# Altium schematic sheet Sheet1.SchDoc(Sheet1)
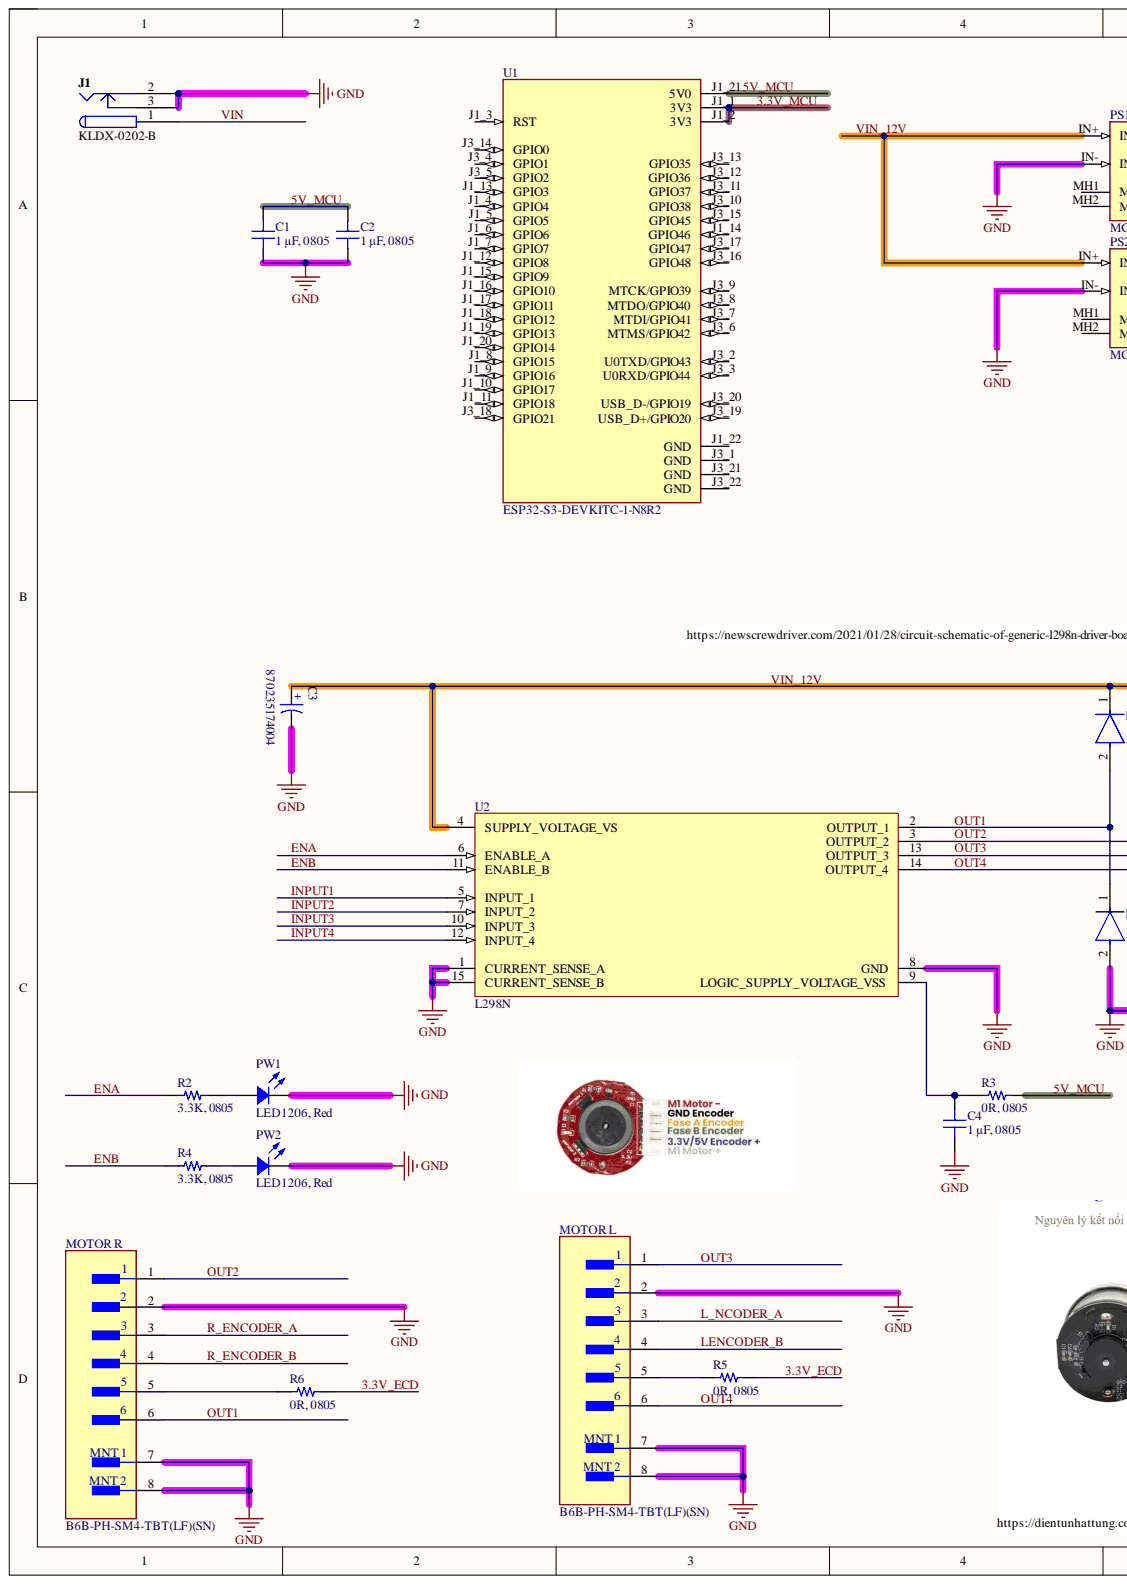
[diagram p0: Sheet1.SchDoc, left]
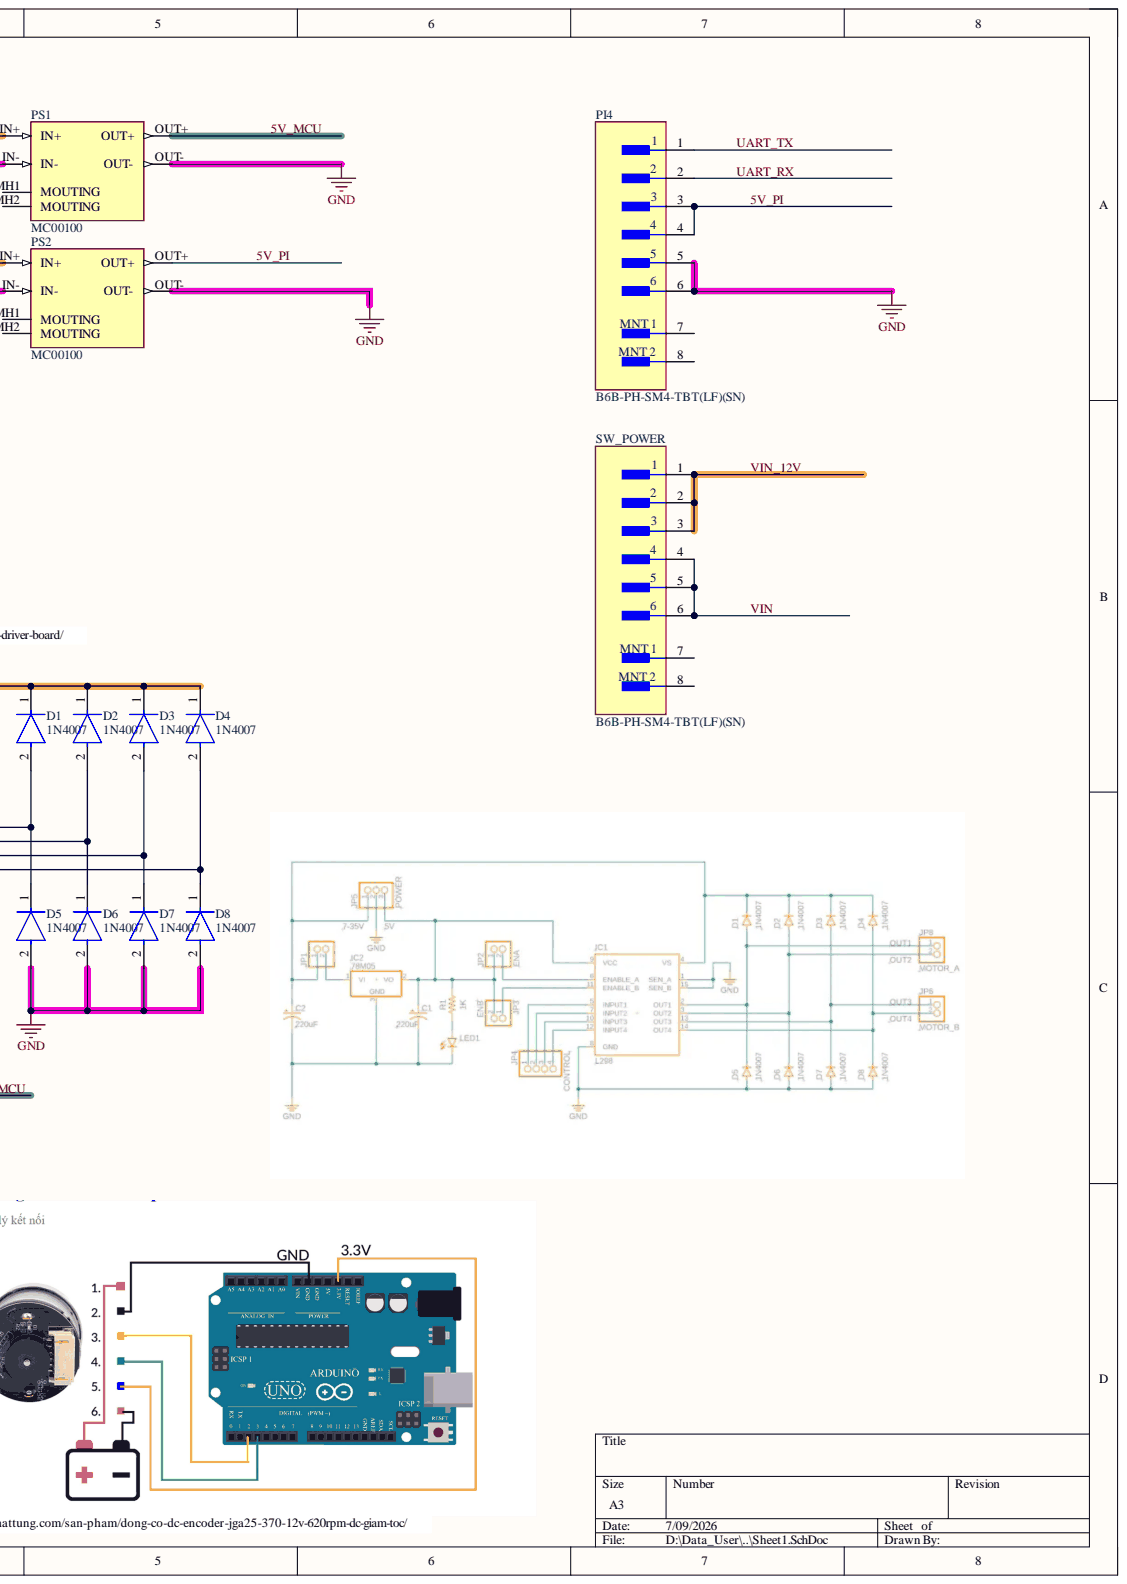
[diagram p1: Sheet1.SchDoc, right]

## Parts
C1  1 µF  [CAP 0805_2012]  (value not drawn)
C2  Capacitance: 1 µF, 0805  [CAP 0805_2012]  (hidden comment: 1 µF)
C3  870235174004  [WCAP-PT5H_D8H11.5]
C4  Capacitance: 1 µF, 0805  [CAP 0805_2012]  (hidden comment: 1 µF)
D1  1N4007  [FP-1N4007-MFG]
D2  1N4007  [FP-1N4007-MFG]
D3  1N4007  [FP-1N4007-MFG]
D4  1N4007  [FP-1N4007-MFG]
D5  1N4007  [FP-1N4007-MFG]
D6  1N4007  [FP-1N4007-MFG]
D7  1N4007  [FP-1N4007-MFG]
D8  1N4007  [FP-1N4007-MFG]
J1  KLDX-0202-B  [KYCN-KLDX-0202-B_V]
MOTOR_L  B6B-PH-SM4-TBT(LF)(SN)  [FP-B6B-PH-SM4-TBT_LF_SN-MFG]
MOTOR_R  B6B-PH-SM4-TBT(LF)(SN)  [FP-B6B-PH-SM4-TBT_LF_SN-MFG]
PI4  B6B-PH-SM4-TBT(LF)(SN)  [FP-B6B-PH-SM4-TBT_LF_SN-MFG]
PS1  MC00100  [CONV_MC00100]
PS2  MC00100  [CONV_MC00100]
PW1  LED1206, Red  value Red  Color: Red  [LED 1206_3216 RED]
PW2  LED1206, Red  value Red  Color: Red  [LED 1206_3216 RED]
R2  3.3K, 0805  Supplier Device Package: 0805  [YAGEO RES 0805_2012]
R3  0R, 0805  Supplier Device Package: 0805  [YAGEO RES 0805_2012]
R4  3.3K, 0805  Supplier Device Package: 0805  [YAGEO RES 0805_2012]
R5  0R, 0805  Supplier Device Package: 0805  [YAGEO RES 0805_2012]
R6  0R, 0805  Supplier Device Package: 0805  [YAGEO RES 0805_2012]
SW_POWER  B6B-PH-SM4-TBT(LF)(SN)  [FP-B6B-PH-SM4-TBT_LF_SN-MFG]
U1  ESP32-S3-DEVKITC-1-N8R2  [XCVR_ESP32-S3-DEVKITC-1-N8R2]
U2  L298N  [L298N_MFG]

## Nets
GND: C1.1 C2.1 C3.2 C4.1 D5.2 D6.2 D7.2 D8.2 J1.2 J1.3 MOTOR_L.2 MOTOR_L.7 MOTOR_L.8 MOTOR_R.2 MOTOR_R.7 MOTOR_R.8 PI4.5 PI4.6 PS1.IN- PS1.OUT- PS2.IN- PS2.OUT- PW1.2 PW2.2 U2.1(CURRENT_SENSE_A) U2.8(GND) U2.15(CURRENT_SENSE_B)
3.3V_ECD: R5.2 R6.2
3.3V_MCU: U1.J1_1(3V3) U1.J1_2(3V3)
5V_MCU: C1.2 C2.2 PS1.OUT+ R3.2 U1.J1_21(5V0)
5V_PI: PI4.3 PI4.4 PS2.OUT+
ENA: R2.1 U2.6(ENABLE_A)
ENB: R4.1 U2.11(ENABLE_B)
INPUT1: U2.5(INPUT_1)
INPUT2: U2.7(INPUT_2)
INPUT3: U2.10(INPUT_3)
INPUT4: U2.12(INPUT_4)
L_NCODER_A: MOTOR_L.3
LENCODER_B: MOTOR_L.4
OUT1: D1.2 D5.1 MOTOR_R.6 U2.2(OUTPUT_1)
OUT2: D2.2 D6.1 MOTOR_R.1 U2.3(OUTPUT_2)
OUT3: D3.2 D7.1 MOTOR_L.1 U2.13(OUTPUT_3)
OUT4: D4.2 D8.1 MOTOR_L.6 U2.14(OUTPUT_4)
R_ENCODER_A: MOTOR_R.3
R_ENCODER_B: MOTOR_R.4
UART_RX: PI4.2
UART_TX: PI4.1
VIN: J1.1 SW_POWER.4 SW_POWER.5 SW_POWER.6
VIN_12V: C3.1 D1.1 D2.1 D3.1 D4.1 PS1.IN+ PS2.IN+ SW_POWER.1 SW_POWER.2 SW_POWER.3 U2.4(SUPPLY_VOLTAGE_VS)
NetC4_2: C4.2 R3.1 U2.9(LOGIC_SUPPLY_VOLTAGE_VSS)
NetMOTOR L_5: MOTOR_L.5 R5.1
NetMOTOR R_5: MOTOR_R.5 R6.1
NetPW1_1: PW1.1 R2.2
NetPW2_1: PW2.1 R4.2

Not connected: PI4 7 8; PS1 MH1(MOUTING) MH2(MOUTING); PS2 MH1(MOUTING) MH2(MOUTING); SW_POWER 7 8; U1 J1_3(RST) J1_4(GPIO4) J1_5(GPIO5) J1_6(GPIO6) J1_7(GPIO7) J1_8(GPIO15) J1_9(GPIO16) J1_10(GPIO17) J1_11(GPIO18) J1_12(GPIO8) J1_13(GPIO3) J1_14(GPIO46) J1_15(GPIO9) J1_16(GPIO10) J1_17(GPIO11) J1_18(GPIO12) J1_19(GPIO13) J1_20(GPIO14) J1_22(GND) J3_1(GND) J3_2(U0TXD/GPIO43) J3_3(U0RXD/GPIO44) J3_4(GPIO1) J3_5(GPIO2) J3_6(MTMS/GPIO42) J3_7(MTDI/GPIO41) J3_8(MTDO/GPIO40) J3_9(MTCK/GPIO39) J3_10(GPIO38) J3_11(GPIO37) J3_12(GPIO36) J3_13(GPIO35) J3_14(GPIO0) J3_15(GPIO45) J3_16(GPIO48) J3_17(GPIO47) J3_18(GPIO21) J3_19(USB_D+/GPIO20) J3_20(USB_D-/GPIO19) J3_21(GND) J3_22(GND)

## Library data of the parts
C1 = CC0805KKX7R9BB105: CAP CER 1UF 50V X7R 0805; manufacturer: Yageo; Capacitance: 1 µF, 0805; Tolerance: ±10%; Applications: General Purpose; Component Kind: Standard; componentlink1description: Datasheet; componentlink1url: https://www.yageo.com/upload/media/product/productsearch/datasheet/mlcc/UPY-GPHC_X7R_6.3V-to-50V_18.pdf; componentlink2description: DigiKey Link; componentlink2url: https://www.digikey.com/en/products/detail/yageo/CC0805KKX7R9BB105/5195292; Device Package: 0805 (2012 Metric); lastupdated: 2/9/2024 8:15:50 PM; Lifecycle Status: Active; Minimum Order: 1; Mounting Type: Surface Mount, MLCC; Operating Temperature: -55°C ~ 125°C; Package / Case: 0805 (2012 Metric); packaging: TapeAndReel; Part Number: CC0805KKX7R9BB105; Part Status: Active; partid: 9887; price: 0.03; series: CC; Size / Dimension: 0.079" L x 0.049" W (2.00mm x 1.25mm); Supplier 1: DigiKey; Supplier Part Number 1: 311-1886-1-ND; Temperature Coefficient: X7R; Thickness (Max): 0.057" (1.45mm); value: 1 µF; Voltage - Rated: 50V; Library Name: TVC_Boad_IO_v1.IntLib
C2 C4 = CC0805KKX7R9BB105: CAP CER 1UF 50V X7R 0805; manufacturer: Yageo; Tolerance: ±10%; Applications: General Purpose; Component Kind: Standard; componentlink1description: Datasheet; componentlink1url: https://www.yageo.com/upload/media/product/productsearch/datasheet/mlcc/UPY-GPHC_X7R_6.3V-to-50V_18.pdf; componentlink2description: DigiKey Link; componentlink2url: https://www.digikey.com/en/products/detail/yageo/CC0805KKX7R9BB105/5195292; Device Package: 0805 (2012 Metric); lastupdated: 2/9/2024 8:15:50 PM; Lifecycle Status: Active; Minimum Order: 1; Mounting Type: Surface Mount, MLCC; Operating Temperature: -55°C ~ 125°C; Package / Case: 0805 (2012 Metric); packaging: TapeAndReel; Part Number: CC0805KKX7R9BB105; Part Status: Active; partid: 9887; price: 0.03; series: CC; Size / Dimension: 0.079" L x 0.049" W (2.00mm x 1.25mm); Supplier 1: DigiKey; Supplier Part Number 1: 311-1886-1-ND; Temperature Coefficient: X7R; Thickness (Max): 0.057" (1.45mm); value: 1 µF; Voltage - Rated: 50V; Library Name: TVC_Boad_IO_v1.IntLib
C3 = CMP-03913-54202634-2: WCAP-PT5H Aluminum Polymer Capacitors; Manufacturer Lifecycle Status: ACTIVE; Capacitance: 680µF; ESR (Equivalent Series Resistance): 7mΩ; Leakage Current: 428µA; Life (Hours): 5000hours; Pitch: 3.5mm; Polarity: Polar; Ripple Current: 6.1A; Tolerance: 20%; Voltage Rating: 6.3V; YEOL: 1 = Estimated lifetime > 10 years; Case/Package: Radial; Component Kind: Standard; Diameter: 8mm; Height - Seated (Max): 13.0048mm; Lead Pitch: 3.5052mm; Length: 11.5mm; Library Name: Altium Content Vault; Lifecycle Status: Production; Max Operating Temperature: 105°C; Min Operating Temperature: -55°C; Mount: Through Hole; Packaging: Tape & Box (TB); REACH SVHC: Unknown; RoHS: Compliant; Voltage Rating (DC): 6.3V
D1-D8 = CMP-123542-000001-1: Rectifier diode 1000 V 1 A DO-41, ,; Manufacturer: Diotec; Capacitance: 15pF; Forward Current: 1A; Max Junction Temp: +175°C; Max Repetitive Reverse Voltage: 1000V; Max Surge Forward Current: 27A; Peak Reverse Current: 5uA; Value: 15pF; Automotive: No; Category: Diode; Component Kind: Standard; ComponentLink2Description: Datasheet; ComponentLink2URL: https://datasheet.octopart.com/1N4007-Diotec-datasheet-62346875.pdf; Device Class L1: Discrete Semiconductors; Device Class L2: Diodes; Device Class L3: Rectifier Diodes; Height: 2.8mm; Ipc Land Pattern Name: DIOAD888W100L520D280; Lead Free: Yes; Library Name: Altium Content Vault; Manufacturer Part Number: 1N4007; Octopart: https://octopart.com/1n4007-diotec-4040411; Package: 1N4007; Reverse Voltage: 1000V; RoHS: TRUE; Temperature: -50°C to +175°C; Temperature Range High: +175°C; Temperature Range Low: -50°C
J1 = CMP-0256-00001-1: 3 Conductor Power Jack, 2 break 2 aux contacts; Component Kind: Standard; ComponentLink1Description: Manufacturer URL; ComponentLink1URL: http://kycon.com/; ComponentLink2Description: Datasheet; ComponentLink2URL: http://anacapa.kycon.com/Catalog_PDF/KLDX_0202.pdf; Contact Finish: Brass; Library Name: Altium Content Vault; Mounting Technology: Through Hole; PackageDescription: Jack, 9 x 14.5 mm Body, 2.5 mm Center Pin Diameter; PackageReference: KLDX-0202-B; PartNumber: KLDX-0202-B
MOTOR_L MOTOR_R PI4 SW_POWER = CMP-17439-000069-1: CONN HEADER SMD 6POS 2MM; Manufacturer: JST; Number of Positions: 6; Component Kind: Standard; Lead Free: Lead Free; Manufacturer Part Number: B6B-PH-SM4-TBT(LF)(SN); Mount: Surface Mount; Pitch: 2mm; Termination: Crimp; Library Name: TVC_Boad_IO_v1.IntLib
PS1 PS2 = MC00100: DC-DC LM2596 Step Down Adjustable Converter Power Supply Module 1.3V-35V; MF: YKS; Check_prices: https://www.snapeda.com/parts/MC00100/YKS/view-part/?ref=eda; Component Kind: Standard; Library Name: MC00100.IntLib; MP: MC00100; Package: None; SnapEDA_Link: https://www.snapeda.com/parts/MC00100/YKS/view-part/?ref=snap
PW1 PW2 = 5990210007F: LED RED 1206 SMD; Manufacturer: Dialight; Configuration: Standard; Current - Test: 20mA; Lens Color: 0.80mm; Lens Size: 2.00mm x 1.60mm; Lens Style: Rectangle with Flat Top; Millicandela Rating: 29mcd; Viewing Angle: 140°; Voltage - Forward (Vf) (Typ): 2.1V; Wavelength - Dominant: 633nm; Component Kind: Standard; ComponentLink1Description: Datasheet; ComponentLink1URL: http://www.dialightsignalsandcomponents.com/Assets/Brochures_And_Catalogs/Indication/CBI_SMD_new/Dialight_CBI_data_599-1206_Apr2018.pdf; ComponentLink2Description: DigiKey Link; ComponentLink2URL: https://www.digikey.com/en/products/detail/dialight/5990210007F/9385415; Device Package: 1206 (3216 Metric); Height (Max): 0.80mm; LastUpdated: 6/3/2024 8:00:41 PM; Lifecycle Status: Active; Minimum Order: 1; Mounting Type: Surface Mount; Package / Case: 1206 (3216 Metric); Packaging: TapeAndReel; Part Number: 5990210007F; Part Status: Active; PartId: 188; Price: 0.04; Series: 599; Size / Dimension: 3.20mm L x 1.60mm W; Supplier 1: DigiKey; Supplier Device Package: 1206; Supplier Part Number 1: 350-4360-1-ND; Library Name: TVC_Boad_IO_v1.IntLib
R2 R4 = RC0805FR-07470RL: RES 470 OHM 1% 1/8W 0805; Manufacturer: Yageo; Power (Watts): 0.125W, 1/8W; Resistance: 470 Ohms; Temperature Coefficient: ±100ppm/°C; Component Kind: Standard; ComponentLink1Description: Datasheet; ComponentLink1URL: https://www.yageo.com/upload/media/product/productsearch/datasheet/rchip/PYu-RC_Group_51_RoHS_L_10.pdf; ComponentLink2Description: DigiKey Link; ComponentLink2URL: https://www.digikey.com/en/products/detail/yageo/RC0805FR-07470RL/727976; Composition: Thick Film; Device Package: 0805 (2012 Metric); Features: Moisture Resistant; Height - Seated (Max): 0.024" (0.60mm); LastUpdated: 7/25/2024 9:20:22 AM; Lifecycle Status: Active; Minimum Order: 1; Number of Terminations: 2; Operating Temperature: -55°C ~ 155°C; Package / Case: 0805 (2012 Metric); Packaging: TapeAndReel; Part Number: RC0805FR-07470RL; Part Status: Active; PartId: 39406; Price: 0.01; Series: RC_L; Size / Dimension: 0.079" L x 0.049" W (2.00mm x 1.25mm); Supplier 1: DigiKey; Supplier Part Number 1: 311-470CRCT-ND; Tolerance: ±1%; Value: 3.3K, 0805; Library Name: TVC_Boad_IO_v1.IntLib
R3 R5 R6 = RC0805FR-07470RL_3: RES 0 OHM 1% 1/8W 0805; Manufacturer: Yageo; Power (Watts): 0.125W, 1/8W; Resistance: 470 Ohms; Temperature Coefficient: ±100ppm/°C; Component Kind: Standard; ComponentLink1Description: Datasheet; ComponentLink1URL: https://www.yageo.com/upload/media/product/productsearch/datasheet/rchip/PYu-RC_Group_51_RoHS_L_10.pdf; ComponentLink2Description: DigiKey Link; ComponentLink2URL: https://www.digikey.com/en/products/detail/yageo/RC0805FR-07470RL/727976; Composition: Thick Film; Device Package: 0805 (2012 Metric); Features: Moisture Resistant; Height - Seated (Max): 0.024" (0.60mm); LastUpdated: 7/25/2024 9:20:22 AM; Lifecycle Status: Active; Minimum Order: 1; Number of Terminations: 2; Operating Temperature: -55°C ~ 155°C; Package / Case: 0805 (2012 Metric); Packaging: TapeAndReel; Part Number: RC0805FR-07470RL; Part Status: Active; PartId: 39406; Price: 0.01; Series: RC_L; Size / Dimension: 0.079" L x 0.049" W (2.00mm x 1.25mm); Supplier 1: DigiKey; Supplier Part Number 1: 311-470CRCT-ND; Tolerance: ±1%; Value: 0R, 0805; Library Name: TVC_Boad_IO_v1.IntLib
U1 = ESP32-S3-DEVKITC-1-N8R2: WiFi Development Tools - 802.11 (Engineering Samples Only) ESP32-S3 General-Purpose Development Board, ESP32-S3-WROOM-1-N8R2, with Pin Header; MF: Espressif Systems; Check_prices: https://www.snapeda.com/parts/ESP32-S3-DEVKITC-1-N8R2/Espressif+Systems/view-part/?ref=eda; Component Kind: Standard; Library Name: ESP32-S3-DEVKITC-1-N8R2.IntLib; MP: ESP32-S3-DEVKITC-1-N8R2; Package: None; SnapEDA_Link: https://www.snapeda.com/parts/ESP32-S3-DEVKITC-1-N8R2/Espressif+Systems/view-part/?ref=snap
U2 = CMP-2000-06526-2: Motor Driver, DC Brush, Full Bridge, 4 Outputs, 4.8V to 46V Supply, 2A Out, Multiwatt-15; Drain to Source Resistance: 930mΩ; Interface: Parallel; Max Collector Current: 3A; Max Output Current: 4A; Max Supply Voltage: 7V; Min Supply Voltage: 4.5V; Motor Type: Brushed; Number of Channels: 2; Number of Outputs: 4; Operating Supply Current: 13mA; Operating Supply Voltage: 5V; Quiescent Current: 500mA; China RoHS: Non-Compliant; Collector Emitter Voltage (VCEO): 46V; Component Kind: Standard; ComponentLink1Description: Manufacturer's Website; ComponentLink1URL: http://www.st.com; ComponentLink2Description: Datasheet URL; ComponentLink2URL: https://www.st.com/content/ccc/resource/technical/document/datasheet/82/cc/3f/39/0a/29/4d/f0/CD00000240.pdf/files/CD00000240.pdf/jcr:content/translations/en.CD00000240.pdf; Height: 10.7mm; Height - Seated (Max): 21mm; HelpURL: https://www.st.com/content/ccc/resource/technical/document/datasheet/82/cc/3f/39/0a/29/4d/f0/CD00000240.pdf/files/CD00000240.pdf/jcr:content/translations/en.CD00000240.pdf; Lead Free: Lead Free; Length: 19.6mm; Library Name: Altium Content Vault; Lifecycle Status: Production; Max Operating Temperature: 150°C; Min Operating Temperature: -40°C; Min Supply Voltage (DC): 4.8V; Mount: Through Hole; Number of Circuits: 2; Number of Drivers: 2; Number of Pins: 13; Number of Terminals: 15; Number of Transmitters: 2; Output Current: 2A; Output Current per Channel: 2A; Output Voltage: 46V; Radiation Hardening: No; REACH SVHC: No; RoHS: Compliant; Schedule B: 8542390000, 8542390000; Terminal Pitch: 1.27mm; Width: 5mm
